# Altium schematic sheet [14] - CONNECTOR.SchDoc("[14] - CONNECTOR")
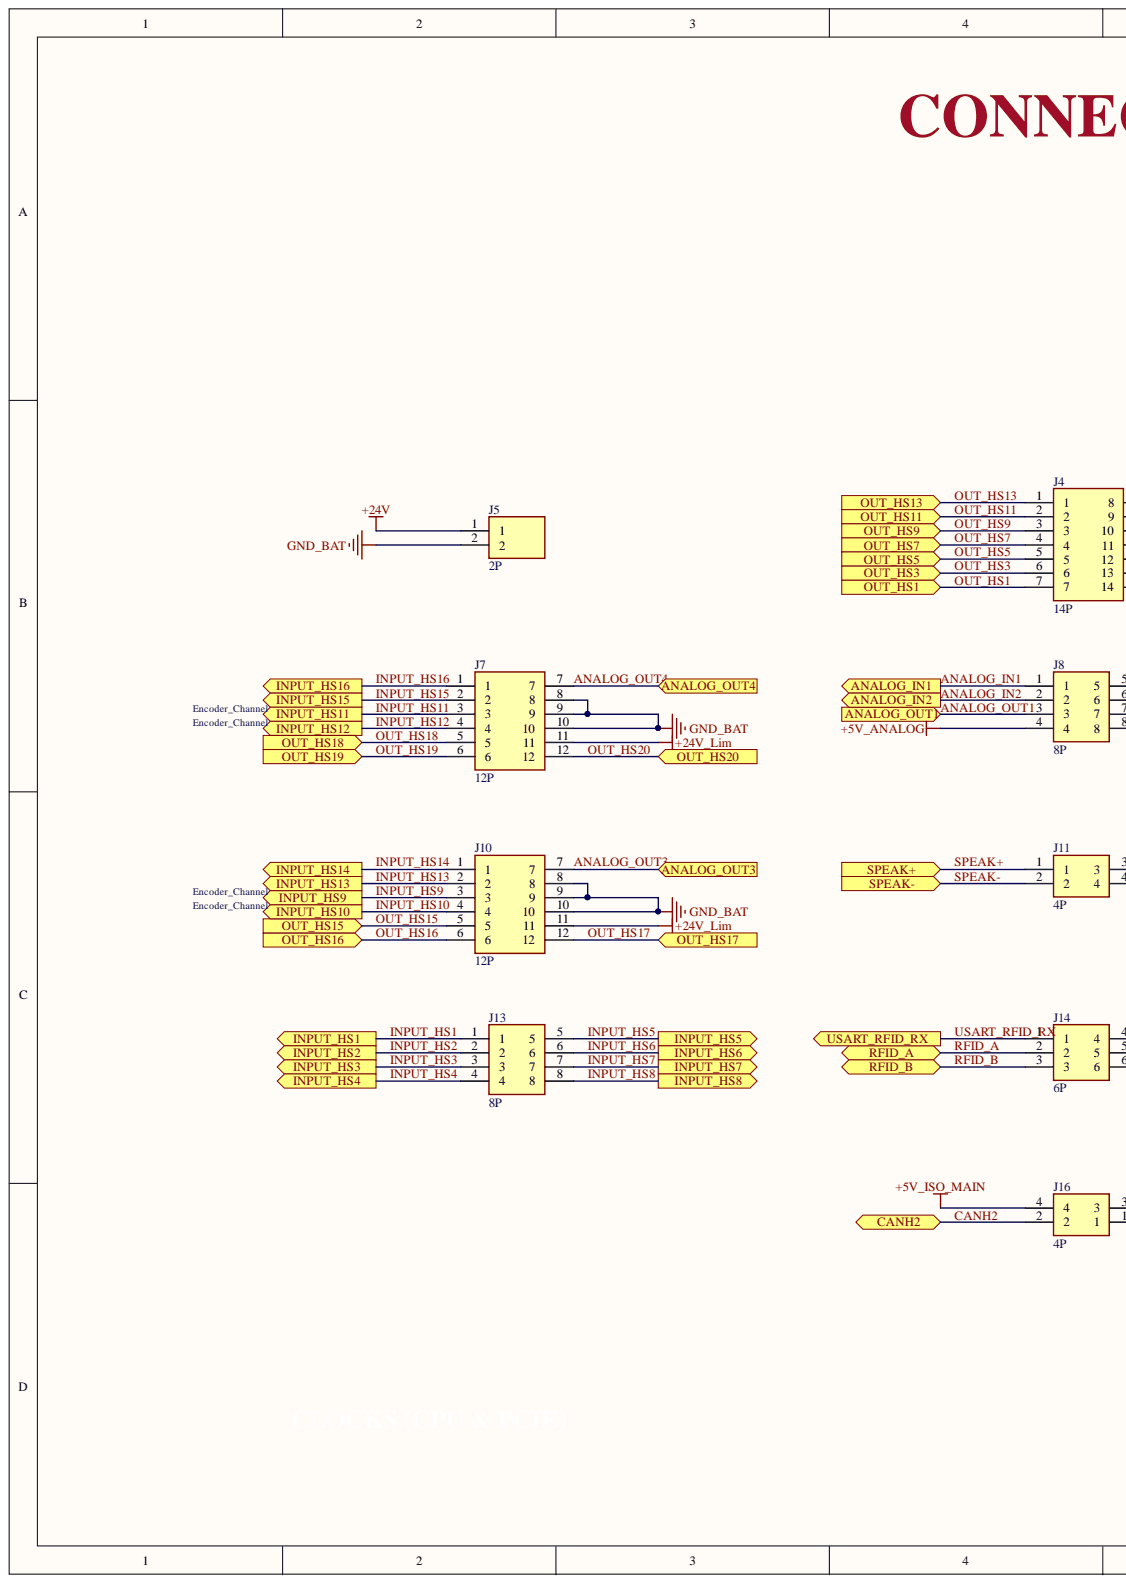
[diagram p0: [14] - CONNECTOR.SchDoc, left]
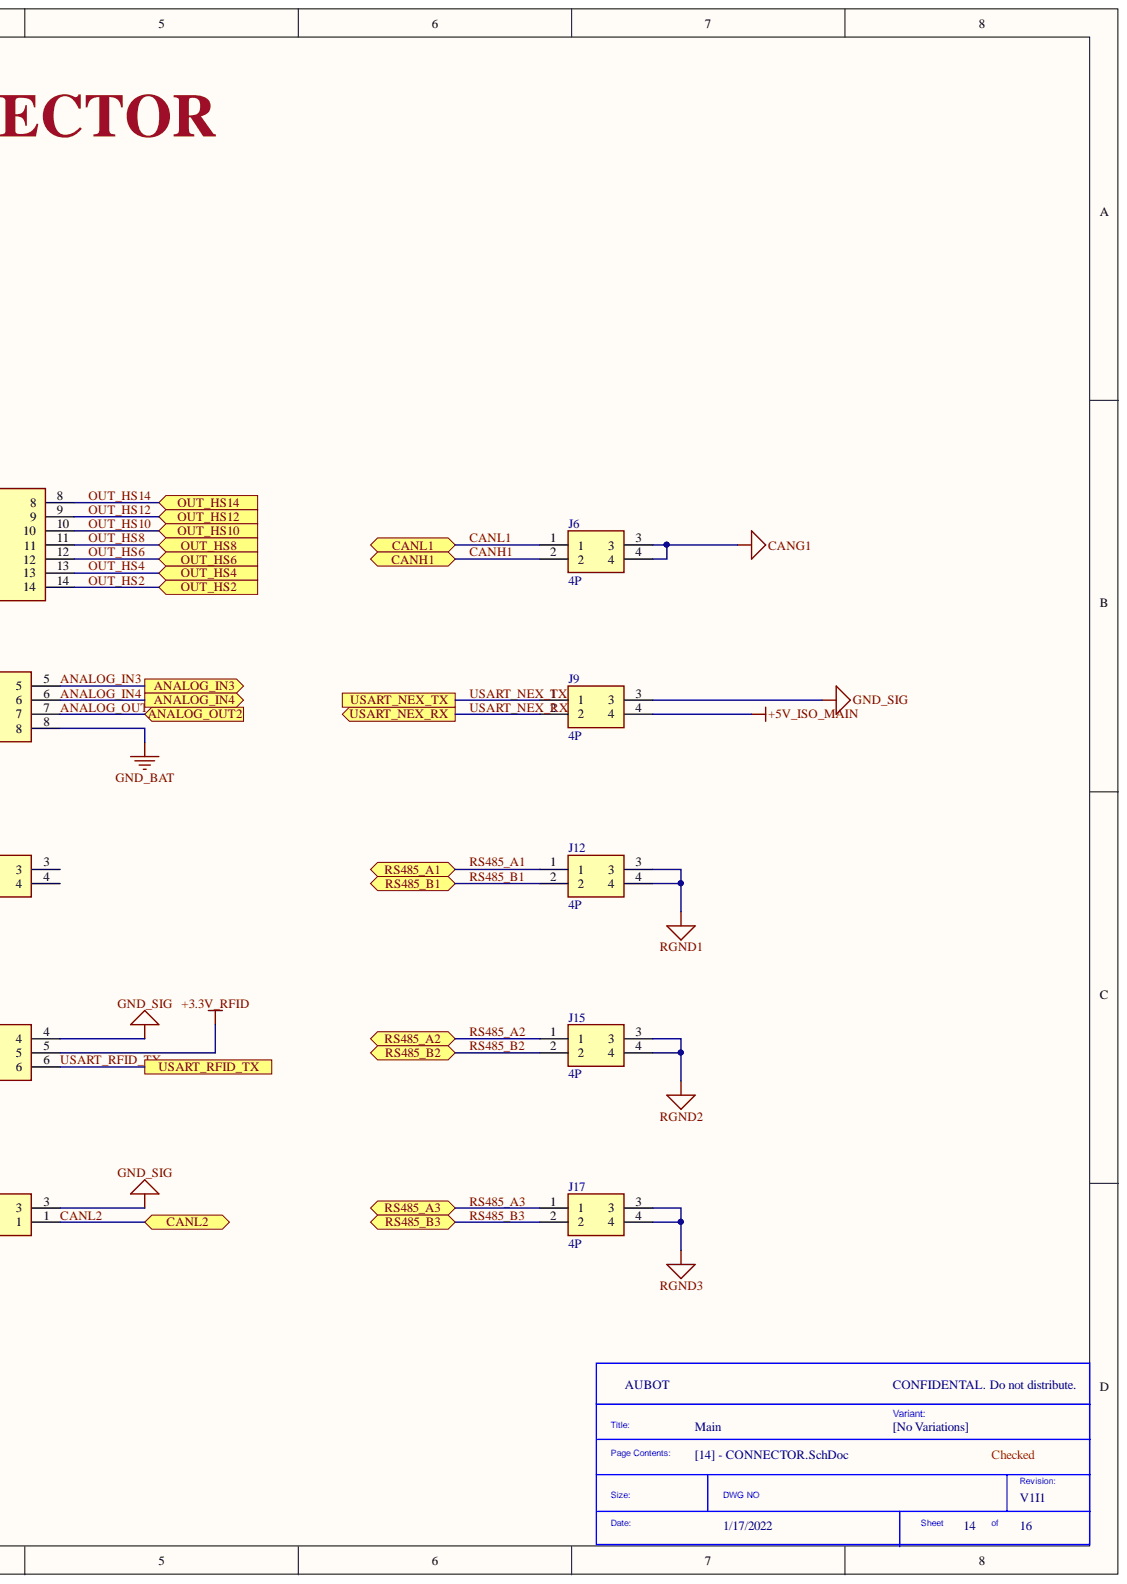
[diagram p1: [14] - CONNECTOR.SchDoc, right]

## Parts
J4  14P  [14P VERTICAL 430451427]
J5  2P  [2P Vertical 430450227]
J6  4P  [4P VERTICAL 430450427]
J7  12P  [12P VERTICAL 430451227]
J8  8P  [8P VERTICAL 430450827]
J9  4P  [4P VERTICAL 430450427]
J10  12P  [12P VERTICAL 430451227]
J11  4P  [4P VERTICAL 430450427]
J12  4P  [4P VERTICAL 430450427]
J13  8P  [8P VERTICAL 430450827]
J14  6P  [6P VERTICAL 430450627]
J15  4P  [4P VERTICAL 430450427]
J16  4P  [4P VERTICAL 430450427]
J17  4P  [4P VERTICAL 430450427]

## Ports
ANALOG_IN1, ANALOG_IN2, ANALOG_IN3, ANALOG_IN4, ANALOG_OUT1, ANALOG_OUT2, ANALOG_OUT3, ANALOG_OUT4, CANH1, CANH2, CANL1, CANL2, INPUT_HS1, INPUT_HS2, INPUT_HS3, INPUT_HS4, INPUT_HS5, INPUT_HS6, INPUT_HS7, INPUT_HS8, INPUT_HS9, INPUT_HS10, INPUT_HS11, INPUT_HS12, INPUT_HS13, INPUT_HS14, INPUT_HS15, INPUT_HS16, OUT_HS1, OUT_HS2, OUT_HS3, OUT_HS4, OUT_HS5, OUT_HS6, OUT_HS7, OUT_HS8, OUT_HS9, OUT_HS10, OUT_HS11, OUT_HS12, OUT_HS13, OUT_HS14, OUT_HS15, OUT_HS16, OUT_HS17, OUT_HS18, OUT_HS19, OUT_HS20, RFID_A, RFID_B, RS485_A1, RS485_A2, RS485_A3, RS485_B1, RS485_B2, RS485_B3, SPEAK+, SPEAK-, USART_NEX_RX, USART_NEX_TX, USART_RFID_RX, USART_RFID_TX

## Nets
+3.3V_RFID: J14.5
+5V_ANALOG: J8.4
+5V_ISO_MAIN: J9.4 J16.4
+24V: J5.1
+24V_Lim: J7.11 J10.11
CANG1: J6.3 J6.4
GND_BAT: J5.2 J7.8 J7.9 J7.10 J8.8 J10.8 J10.9 J10.10
GND_SIG: J9.3 J14.4 J16.3
RGND1: J12.3 J12.4
RGND2: J15.3 J15.4
RGND3: J17.3 J17.4
ANALOG_IN1: J8.1 ; port ANALOG_IN1
ANALOG_IN2: J8.2 ; port ANALOG_IN2
ANALOG_IN3: J8.5 ; port ANALOG_IN3
ANALOG_IN4: J8.6 ; port ANALOG_IN4
ANALOG_OUT1: J8.3 ; port ANALOG_OUT1
ANALOG_OUT2: J8.7 ; port ANALOG_OUT2
ANALOG_OUT3: J10.7 ; port ANALOG_OUT3
ANALOG_OUT4: J7.7 ; port ANALOG_OUT4
CANH1: J6.2 ; port CANH1
CANH2: J16.2 ; port CANH2
CANL1: J6.1 ; port CANL1
CANL2: J16.1 ; port CANL2
INPUT_HS1: J13.1 ; port INPUT_HS1
INPUT_HS2: J13.2 ; port INPUT_HS2
INPUT_HS3: J13.3 ; port INPUT_HS3
INPUT_HS4: J13.4 ; port INPUT_HS4
INPUT_HS5: J13.5 ; port INPUT_HS5
INPUT_HS6: J13.6 ; port INPUT_HS6
INPUT_HS7: J13.7 ; port INPUT_HS7
INPUT_HS8: J13.8 ; port INPUT_HS8
INPUT_HS9: J10.3 ; port INPUT_HS9
INPUT_HS10: J10.4 ; port INPUT_HS10
INPUT_HS11: J7.3 ; port INPUT_HS11
INPUT_HS12: J7.4 ; port INPUT_HS12
INPUT_HS13: J10.2 ; port INPUT_HS13
INPUT_HS14: J10.1 ; port INPUT_HS14
INPUT_HS15: J7.2 ; port INPUT_HS15
INPUT_HS16: J7.1 ; port INPUT_HS16
OUT_HS1: J4.7 ; port OUT_HS1
OUT_HS2: J4.14 ; port OUT_HS2
OUT_HS3: J4.6 ; port OUT_HS3
OUT_HS4: J4.13 ; port OUT_HS4
OUT_HS5: J4.5 ; port OUT_HS5
OUT_HS6: J4.12 ; port OUT_HS6
OUT_HS7: J4.4 ; port OUT_HS7
OUT_HS8: J4.11 ; port OUT_HS8
OUT_HS9: J4.3 ; port OUT_HS9
OUT_HS10: J4.10 ; port OUT_HS10
OUT_HS11: J4.2 ; port OUT_HS11
OUT_HS12: J4.9 ; port OUT_HS12
OUT_HS13: J4.1 ; port OUT_HS13
OUT_HS14: J4.8 ; port OUT_HS14
OUT_HS15: J10.5 ; port OUT_HS15
OUT_HS16: J10.6 ; port OUT_HS16
OUT_HS17: J10.12 ; port OUT_HS17
OUT_HS18: J7.5 ; port OUT_HS18
OUT_HS19: J7.6 ; port OUT_HS19
OUT_HS20: J7.12 ; port OUT_HS20
RFID_A: J14.2 ; port RFID_A
RFID_B: J14.3 ; port RFID_B
RS485_A1: J12.1 ; port RS485_A1
RS485_A2: J15.1 ; port RS485_A2
RS485_A3: J17.1 ; port RS485_A3
RS485_B1: J12.2 ; port RS485_B1
RS485_B2: J15.2 ; port RS485_B2
RS485_B3: J17.2 ; port RS485_B3
SPEAK+: J11.1 ; port SPEAK+
SPEAK-: J11.2 ; port SPEAK-
USART_NEX_RX: J9.2 ; port USART_NEX_RX
USART_NEX_TX: J9.1 ; port USART_NEX_TX
USART_RFID_RX: J14.1 ; port USART_RFID_RX
USART_RFID_TX: J14.6 ; port USART_RFID_TX

Not connected: J11 3 4

## Library data of the parts
J4 = 14P Microfit 3.0 Vertical 43045-1427: Wire-To-Board Connector, 3 mm, 14 Contacts, Header, Micro-Fit 3.0 43045 Series, Through Hole; Manufacturer: Molex; Voltage Rating: 600V; Row Spacing: 3mm; Number of Positions: 14; Max Voltage Rating (DC): 250.25kV; Glow Wire Compliant: Compliant; Gender: Male; Current Rating: 5A; Contact Resistance: 10mR; Termination: Through Hole; Supplier Part Number 1: WM10707-ND; Supplier 1: Digi-Key; Stock 1: 1392; Series: 43045; RoHS Compliant: Yes; RoHS: Yes; Pricing 1: 1 = 3.77000, 10 = 3.31600, 80 = 2.63788, 1872 = 2.11033, 2808 = 2.03496 (USD); Pitch: 3mm; Packaging: Tray; Package Quantity: 936; Orientation: Vertical; Number of Rows: 2; Number of Contacts: 14; Number of Circuits: 14; Mount: Through Hole; Min Operating Temperature: -40°C; Max Operating Temperature: 105°C; Manufacturer Part Number: 43045-1427; Housing Material: Thermoplastic; Halogen Free: Low Halogen; Contact Plating: Tin; Contact Material: Brass; ComponentLink2URL: https://datasheet.ciiva.com/30158/174180430451427-pcb-headers-30158968.pdf?src-supplier=Onlinecomponents.com; ComponentLink2Description: https://datasheet.ciiva.com/30158/174180430451427-pcb-headers-30158968.pdf?src-supplier=Onlinecomponents.com; ComponentLink1URL: https://datasheet.ciiva.com/5162/0430451427-pcb-headers-168212-5162188.pdf?src-supplier=Mouser; ComponentLink1Description: https://datasheet.ciiva.com/5162/0430451427-pcb-headers-168212-5162188.pdf?src-supplier=Mouser; Component Kind: Standard; Library Name: Hieu_Lib.IntLib
J5 = 2P Microfit 3.0 Vertical 43045-0227: Conn Wire to Board HDR 2Power POS Solder ST Top Entry Thru-Hole Micro-Fit 3.0 Tray; Manufacturer: Molex; Voltage Rating: 600V; Row Spacing: 3mm; Number of Terminals/Pins: 2; Number of Ports: 1; Max Voltage Rating (DC): 250.25kV; Glow Wire Compliant: Compliant; Gender: Male; Current Rating: 5A; Contact Resistance: 10mR; Termination: Solder; Supplier Part Number 1: WM10657-ND; Supplier 1: Digi-Key; Stock 1: 23681; RoHS: Yes; Radiation Hardening: No; Pricing 1: 1 = 0.97000, 10 = 0.80600, 80 = 0.67200, 945 = 0.53760, 2400 = 0.48720 (USD); Pitch: 3mm; Packaging: Tray; Package Quantity: 3168; Orientation: Vertical; Number of Rows: 2; Number of Positions: 2; Number of Contacts: 2; Number of Circuits: 2; Mount: Through Hole; Min Operating Temperature: -40°C; Max Operating Temperature: 105°C; Manufacturer Part Number: 43045-0227; Length: 7.15mm; Housing Material: Thermoplastic; Housing Color: Black; Halogen Free: Low Halogen; Depth: 8.77mm; Contact Plating: Tin; Contact Material: Brass; ComponentLink6URL: https://datasheet.ciiva.com/16725/0430450227-pcb-headers-16725483.pdf?src-supplier=Avnet+Europe; ComponentLink6Description: https://datasheet.ciiva.com/16725/0430450227-pcb-headers-16725483.pdf?src-supplier=Avnet+Europe; ComponentLink5URL: https://datasheet.ciiva.com/10089/0430450227-pcb-headers-10089453.pdf?src-supplier=Avnet; ComponentLink5Description: https://datasheet.ciiva.com/10089/0430450227-pcb-headers-10089453.pdf?src-supplier=Avnet; ComponentLink4URL: https://datasheet.ciiva.com/5148/0430450227-pcb-headers-142611-5148694.pdf?src-supplier=Mouser; ComponentLink4Description: https://datasheet.ciiva.com/5148/0430450227-pcb-headers-142611-5148694.pdf?src-supplier=Mouser; ComponentLink3URL: https://datasheet.ciiva.com/30256/212010430450227-pcb-headers-30256881.pdf?src-supplier=Onlinecomponents.com; ComponentLink3Description: https://datasheet.ciiva.com/30256/212010430450227-pcb-headers-30256881.pdf?src-supplier=Onlinecomponents.com; ComponentLink2URL: https://datasheet.ciiva.com/11752/0900766b80d4a80b-11752890.pdf?src-supplier=RSComponents; ComponentLink2Description: https://datasheet.ciiva.com/11752/0900766b80d4a80b-11752890.pdf?src-supplier=RSComponents; ComponentLink1URL: https://datasheet.ciiva.com/16103/307170430450227-pcb-headers-16103122.pdf?src-supplier=Onlinecomponents.com; ComponentLink1Description: https://datasheet.ciiva.com/16103/307170430450227-pcb-headers-16103122.pdf?src-supplier=Onlinecomponents.com; Component Kind: Standard; Library Name: Hieu_Lib.IntLib
J6 J9 J11 J12 J15-J17 = 4P Microfit 3.0 Vertical 43045-0427: Conn Header 4 POS 3mm Solder ST Thru-Hole 4 Terminal 1 Port Micro-Fit 3.0 Tray; Manufacturer: Molex; Voltage Rating: 600V; Number of Positions: 4; Max Voltage Rating (DC): 250.25kV; Glow Wire Compliant: Compliant; Current Rating: 5A; Contact Resistance: 10mR; Termination: Solder; Supplier Part Number 1: WM10667-ND; Supplier 1: Digi-Key; Stock 1: 0; Row Spacing: 3mm; RoHS: Yes; REACH SVHC: No SVHC; Radiation Hardening: No; Pricing 1: 1 = 1.45000, 10 = 1.23200, 80 = 1.01750, 2232 = 0.77647, 4464 = 0.74970 (USD); Pitch: 3mm; Packaging: Tray; Package Quantity: 2232; Orientation: Vertical; Number of Rows: 2; Number of Contacts: 4; Number of Circuits: 4; Mount: Through Hole; Min Operating Temperature: -40°C; Max Operating Temperature: 105°C; Manufacturer Part Number: 43045-0427; Housing Material: Thermoplastic; Halogen Free: Low Halogen; Contact Plating: Tin; Contact Material: Brass; ComponentLink5URL: https://datasheet.ciiva.com/10088/0430450427-pcb-headers-10088767.pdf?src-supplier=Avnet; ComponentLink5Description: https://datasheet.ciiva.com/10088/0430450427-pcb-headers-10088767.pdf?src-supplier=Avnet; ComponentLink4URL: https://datasheet.ciiva.com/16725/0430450427-pcb-headers-16725499.pdf?src-supplier=Avnet+Europe; ComponentLink4Description: https://datasheet.ciiva.com/16725/0430450427-pcb-headers-16725499.pdf?src-supplier=Avnet+Europe; ComponentLink3URL: https://datasheet.ciiva.com/11748/0900766b80d4a80b-11748554.pdf?src-supplier=RSComponents; ComponentLink3Description: https://datasheet.ciiva.com/11748/0900766b80d4a80b-11748554.pdf?src-supplier=RSComponents; ComponentLink2URL: https://datasheet.ciiva.com/5148/0430450427-pcb-headers-142988-5148953.pdf?src-supplier=Mouser; ComponentLink2Description: https://datasheet.ciiva.com/5148/0430450427-pcb-headers-142988-5148953.pdf?src-supplier=Mouser; ComponentLink1URL: https://datasheet.ciiva.com/30143/176090430450427-pcb-headers-30143652.pdf?src-supplier=Onlinecomponents.com; ComponentLink1Description: https://datasheet.ciiva.com/30143/176090430450427-pcb-headers-30143652.pdf?src-supplier=Onlinecomponents.com; Component Kind: Standard; Library Name: Hieu_Lib.SchLib
J7 J10 = 12P Microfit 3.0 Vertical 43045-1227: Conn Power HDR 12 POS 3mm Solder ST Thru-Hole 12 Terminal 1 Port Micro-Fit 3.0™ Frame; Manufacturer: Molex; Voltage Rating: 600V; Number of Positions: 12; Glow Wire Compliant: Compliant; Gender: Male; Current Rating: 5A; Termination: Through Hole; Supplier Part Number 1: WM10697-ND; Supplier 1: Digi-Key; Stock 1: 0; Series: 43045; Row Spacing: 3mm; RoHS Compliant: Yes; RoHS: Yes; Pricing 1: 1 = 2.77000, 10 = 2.43700, 80 = 1.93838, 1008 = 1.60608, 3024 = 1.49532 (USD); Pitch: 3mm; Packaging: Tray; Package Quantity: 1008; Orientation: Vertical; Number of Rows: 2; Number of Contacts: 12; Number of Circuits: 12; Manufacturer Part Number: 43045-1227; Housing Material: Thermoplastic; Halogen Free: Low Halogen; Contact Plating: Tin; Contact Material: Brass; ComponentLink2URL: https://datasheet.ciiva.com/7814/1629779-7814795.pdf?src-supplier=Element14; ComponentLink2Description: https://datasheet.ciiva.com/7814/1629779-7814795.pdf?src-supplier=Element14; ComponentLink1URL: https://datasheet.ciiva.com/13676/0430451227-pcb-headers-143012-13676689.pdf?src-supplier=Mouser; ComponentLink1Description: https://datasheet.ciiva.com/13676/0430451227-pcb-headers-143012-13676689.pdf?src-supplier=Mouser; Component Kind: Standard; Library Name: Hieu_Lib.IntLib
J8 J13 = 8P Microfit 3.0 Vertical 43045-0827: Header Connector, pcb Mount, recept, 8 Contacts, pin, 0.118 Pitch, pc Tail Terminal, polarized Lck; Manufacturer: Molex; Voltage Rating: 250V; Row Spacing: 3mm; Number of Positions: 8; Glow Wire Compliant: Compliant; Gender: Male; Current Rating: 5A; Termination: Through Hole; Supplier Part Number 1: WM10684-ND; Supplier 1: Digi-Key; Stock 1: 22569; Series: 43045; RoHS Compliant: Yes; RoHS: Yes; REACH SVHC: Unknown; Radiation Hardening: No; Pricing 1: 1 = 1.94000, 10 = 1.65000, 80 = 1.36338, 1440 = 1.07635, 2880 = 1.00460 (USD); Pitch: 3mm; Packaging: Tray; Package Quantity: 1440; Orientation: Vertical; Number of Rows: 2; Number of Contacts: 8; Number of Circuits: 8; Manufacturer Part Number: 43045-0827; Housing Material: Thermoplastic; Halogen Free: Low Halogen; Contact Plating: Tin; Contact Material: Brass; ComponentLink5URL: https://datasheet.ciiva.com/16736/0430450827-pcb-headers-16736822.pdf?src-supplier=Avnet+Europe; ComponentLink5Description: https://datasheet.ciiva.com/16736/0430450827-pcb-headers-16736822.pdf?src-supplier=Avnet+Europe; ComponentLink4URL: https://datasheet.ciiva.com/25286/1631462-25286604.pdf?src-supplier=Newark; ComponentLink4Description: https://datasheet.ciiva.com/25286/1631462-25286604.pdf?src-supplier=Newark; ComponentLink3URL: https://datasheet.ciiva.com/11747/0900766b80d6135b-11747129.pdf?src-supplier=RSComponents; ComponentLink3Description: https://datasheet.ciiva.com/11747/0900766b80d6135b-11747129.pdf?src-supplier=RSComponents; ComponentLink2URL: https://datasheet.ciiva.com/10085/0430450827-pcb-headers-10085187.pdf?src-supplier=Avnet; ComponentLink2Description: https://datasheet.ciiva.com/10085/0430450827-pcb-headers-10085187.pdf?src-supplier=Avnet; ComponentLink1URL: https://datasheet.ciiva.com/13678/0430450827-pcb-headers-142833-13678393.pdf?src-supplier=Mouser; ComponentLink1Description: https://datasheet.ciiva.com/13678/0430450827-pcb-headers-142833-13678393.pdf?src-supplier=Mouser; Component Kind: Standard; Library Name: Hieu_Lib.IntLib
J14 = 6P Microfit 3.0 Vertical 43045-0627: Conn Wire to Board HDR 6 POS 3mm Solder ST Thru-Hole Micro-Fit 3.0™ Tray; Manufacturer: Molex; Voltage Rating: 250V; Number of Positions: 6; Max Voltage Rating (DC): 250.25kV; Glow Wire Compliant: Compliant; Gender: Male; Current Rating: 5A; Contact Resistance: 10mR; Termination: Solder; Supplier Part Number 1: WM10673-ND; Supplier 1: Digi-Key; Stock 1: 2238; Row Spacing: 3mm; RoHS: Yes; REACH SVHC: No SVHC; Radiation Hardening: No; Pricing 1: 1 = 1.83000, 10 = 1.56200, 80 = 1.29000, 1728 = 0.98445, 3456 = 0.95050 (USD); Pitch: 3mm; Packaging: Tray; Package Quantity: 1728; Orientation: Vertical; Number of Rows: 2; Number of Contacts: 6; Number of Circuits: 6; Mount: Through Hole; Min Operating Temperature: -40°C; Max Operating Temperature: 105°C; Manufacturer Part Number: 43045-0627; Housing Material: Thermoplastic; Halogen Free: Low Halogen; Contact Plating: Tin; Contact Material: Brass; ComponentLink5URL: https://datasheet.ciiva.com/10086/0430450627-pcb-headers-10086347.pdf?src-supplier=Avnet; ComponentLink5Description: https://datasheet.ciiva.com/10086/0430450627-pcb-headers-10086347.pdf?src-supplier=Avnet; ComponentLink4URL: https://datasheet.ciiva.com/5147/0430450627-pcb-headers-142829-5147795.pdf?src-supplier=Mouser; ComponentLink4Description: https://datasheet.ciiva.com/5147/0430450627-pcb-headers-142829-5147795.pdf?src-supplier=Mouser; ComponentLink3URL: https://datasheet.ciiva.com/11749/0900766b80d6135b-11749158.pdf?src-supplier=RSComponents; ComponentLink3Description: https://datasheet.ciiva.com/11749/0900766b80d6135b-11749158.pdf?src-supplier=RSComponents; ComponentLink2URL: https://datasheet.ciiva.com/16725/0430450627-pcb-headers-16725522.pdf?src-supplier=Avnet+Europe; ComponentLink2Description: https://datasheet.ciiva.com/16725/0430450627-pcb-headers-16725522.pdf?src-supplier=Avnet+Europe; ComponentLink1URL: https://datasheet.ciiva.com/30120/86890430450627-pcb-headers-30120981.pdf?src-supplier=Onlinecomponents.com; ComponentLink1Description: https://datasheet.ciiva.com/30120/86890430450627-pcb-headers-30120981.pdf?src-supplier=Onlinecomponents.com; Component Kind: Standard; Library Name: Hieu_Lib.IntLib
